# Altium schematic sheet Sheet1.SchDoc
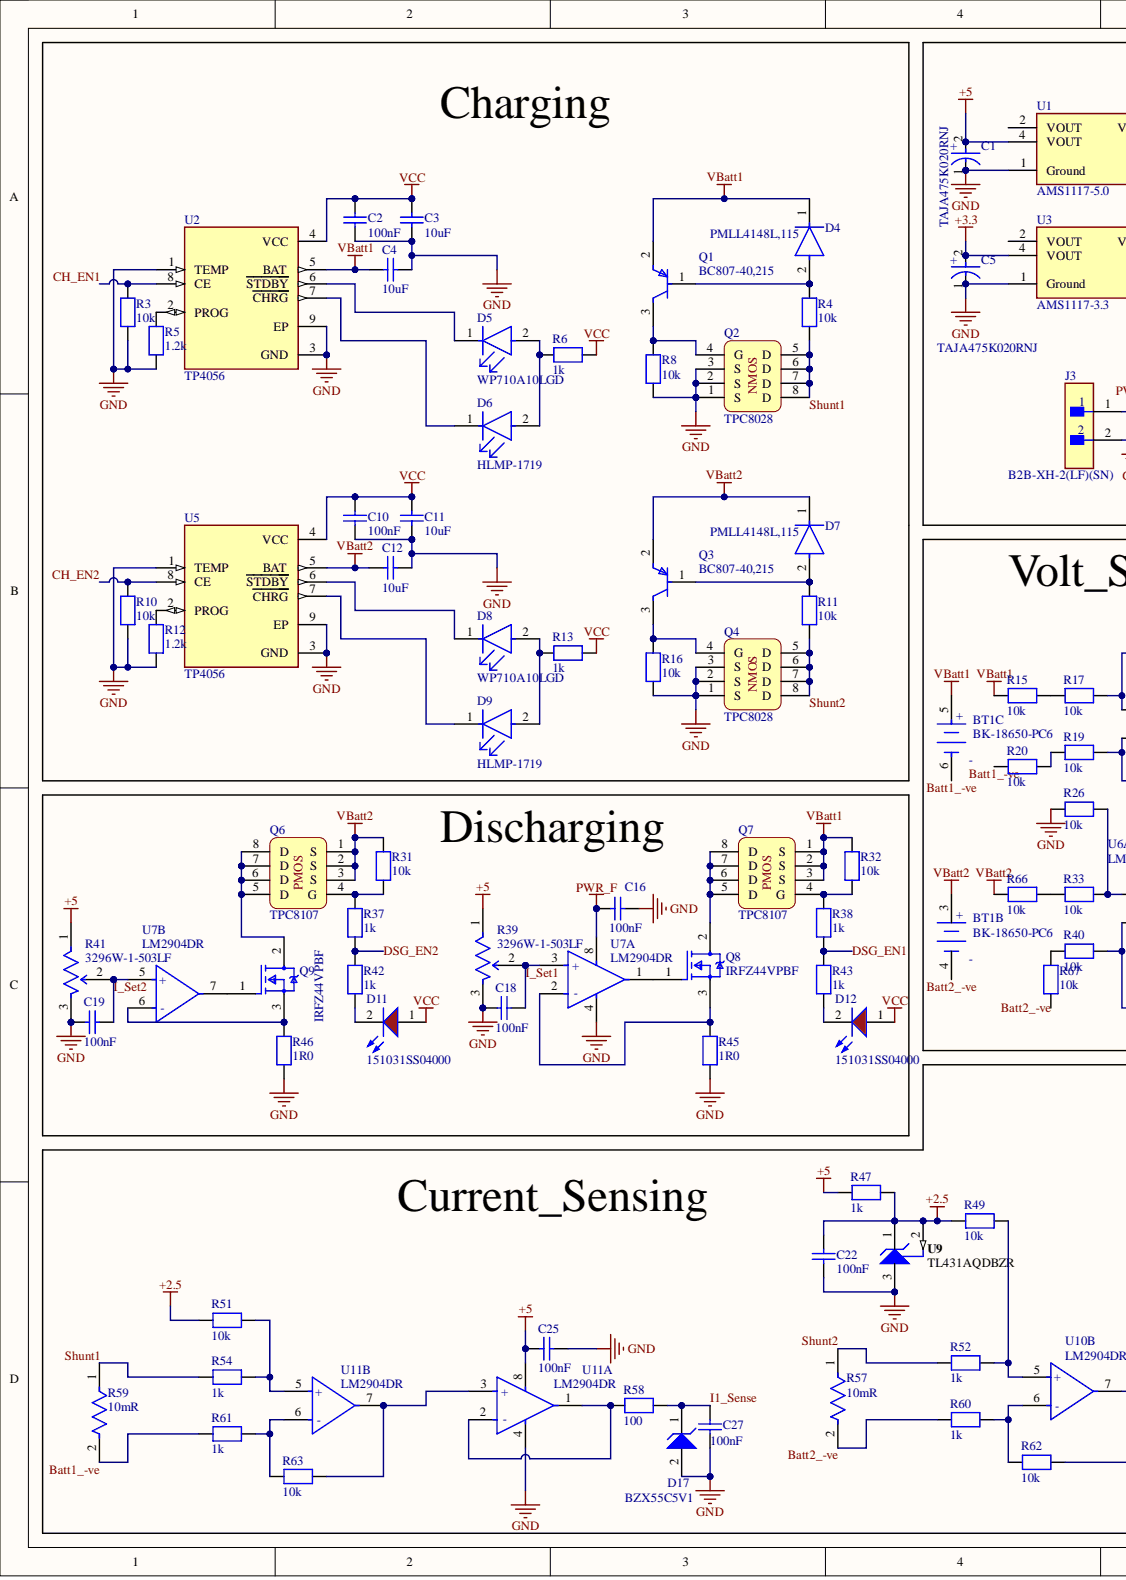
[diagram p0: Sheet1.SchDoc, left]
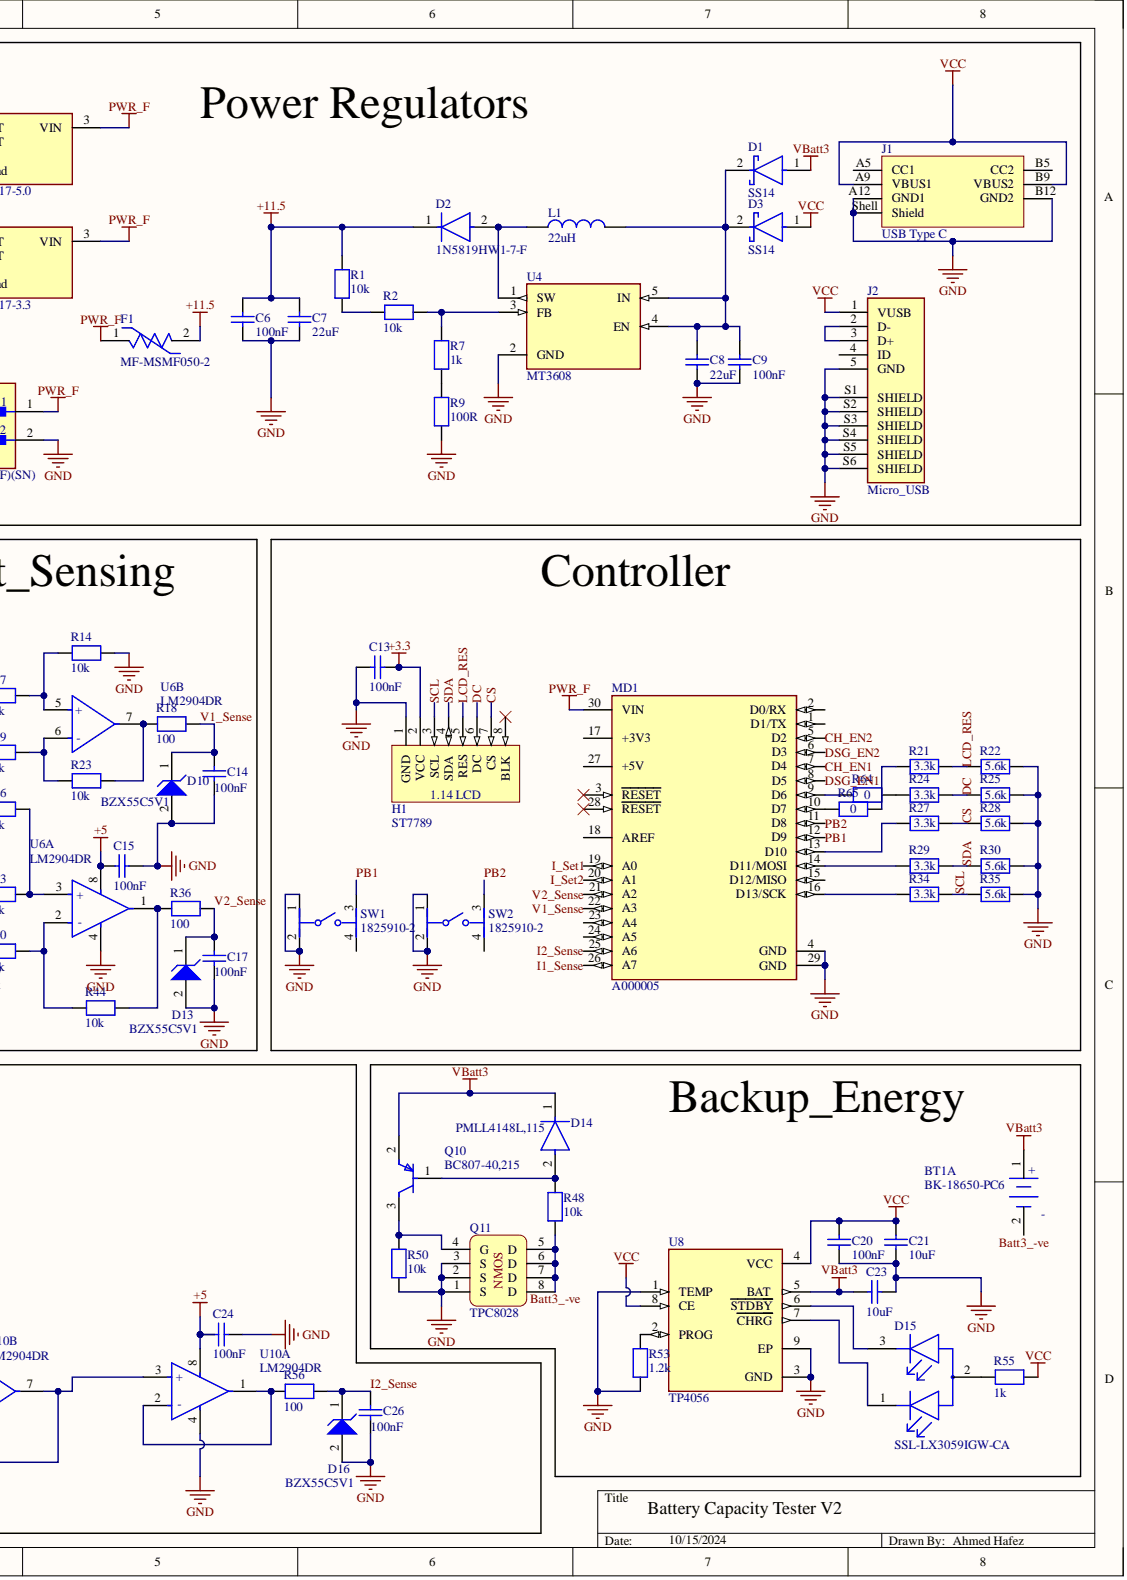
[diagram p1: Sheet1.SchDoc, right]

## Parts
BT1  BK-18650-PC6  [FP-BK-18650-PC6-MFG]  (parts A,B,C)
C1  TAJA475K020RNJ  [FP-TAJA-MFG]
C2  value 100nF  [CAP_0805]  (hidden comment: CAP_Generic)
C3  value 10uF  [CAP_0805]  (hidden comment: CAP_Generic)
C4  value 10uF  [CAP_0805]  (hidden comment: CAP_Generic)
C5  TAJA475K020RNJ  [FP-TAJA-MFG]
C6  value 100nF  [CAP_0603]  (hidden comment: CAP_Generic)
C7  value 22uF  [CAP_1206]  (hidden comment: CAP_Generic)
C8  value 22uF  [CAP_1206]  (hidden comment: CAP_Generic)
C9  value 100nF  [CAP_0603]  (hidden comment: CAP_Generic)
C10  value 100nF  [CAP_0805]  (hidden comment: CAP_Generic)
C11  value 10uF  [CAP_0805]  (hidden comment: CAP_Generic)
C12  value 10uF  [CAP_0805]  (hidden comment: CAP_Generic)
C13  value 100nF  [CAP_0603]  (hidden comment: CAP_Generic)
C14  value 100nF  [CAP_0603]  (hidden comment: CAP_Generic)
C15  value 100nF  [CAP_0603]  (hidden comment: CAP_Generic)
C16  value 100nF  [CAP_0603]  (hidden comment: CAP_Generic)
C17  value 100nF  [CAP_0603]  (hidden comment: CAP_Generic)
C18  value 100nF  [CAP_0603]  (hidden comment: CAP_Generic)
C19  value 100nF  [CAP_0603]  (hidden comment: CAP_Generic)
C20  value 100nF  [CAP_0805]  (hidden comment: CAP_Generic)
C21  value 10uF  [CAP_0805]  (hidden comment: CAP_Generic)
C22  value 100nF  [CAP_0603]  (hidden comment: CAP_Generic)
C23  value 10uF  [CAP_0805]  (hidden comment: CAP_Generic)
C24  value 100nF  [CAP_0603]  (hidden comment: CAP_Generic)
C25  value 100nF  [CAP_0603]  (hidden comment: CAP_Generic)
C26  value 100nF  [CAP_0603]  (hidden comment: CAP_Generic)
C27  value 100nF  [CAP_0603]  (hidden comment: CAP_Generic)
D1  SS14  [FP-403AE-MFG]
D2  1N5819HW1-7-F  [FP-SOD123F_Type_B-MFG]
D3  SS14  [FP-403AE-MFG]
D4  PMLL4148L,115  [FP-SOD80C-MFG]
D5  WP710A10LGD  [FP-WP710A10LGD-MFG]
D6  HLMP-1719  [FP-HLMP-1719-MFG]
D7  PMLL4148L,115  [FP-SOD80C-MFG]
D8  WP710A10LGD  [FP-WP710A10LGD-MFG]
D9  HLMP-1719  [FP-HLMP-1719-MFG]
D10  BZX55C5V1  [DO-35 (DO-204AH)]
D11  151031SS04000  [WL-TMRC_D3.95H5.3]
D12  151031SS04000  [WL-TMRC_D3.95H5.3]
D13  BZX55C5V1  [DO-35 (DO-204AH)]
D14  PMLL4148L,115  [FP-SOD80C-MFG]
D15  SSL-LX3059IGW-CA  [FP-SSL-LX3059IGW-CA-MFG]
D16  BZX55C5V1  [DO-35 (DO-204AH)]
D17  BZX55C5V1  [DO-35 (DO-204AH)]
F1  MF-MSMF050-2  [FP-MF-MSMF050-2-MFG]
H1  ST7789  [1.3-tft-ips-module-st7789]
J1  USB Type C  [632722100213]
J2  Micro_USB  [FCI_10118193-0001LF]
J3  B2B-XH-2(LF)(SN)  [FP-B2B-XH-2_LF_SN-MFG]
L1  value 22uH  [CD43-220M]  (hidden comment: CD43-220M)
MD1  A000005  [FP-A000005-MFG]
Q1  BC807-40,215  [FP-SOT23-IPC_A]
Q2  TPC8028  [FP-751-07-MFG]
Q3  BC807-40,215  [FP-SOT23-IPC_A]
Q4  TPC8028  [FP-751-07-MFG]
Q6  TPC8107  [FP-751-07-MFG]
Q7  TPC8107  [FP-751-07-MFG]
Q8  IRFZ44VPBF  [FP-PG-TO220-3-MFG]
Q9  IRFZ44VPBF  [FP-PG-TO220-3-MFG]
Q10  BC807-40,215  [FP-SOT23-IPC_A]
Q11  TPC8028  [FP-751-07-MFG]
R1  value 10k  [RES_0603]  (hidden comment: RES_Generic)
R2  value 10k  [RES_0603]  (hidden comment: RES_Generic)
R3  value 10k  [RES_0603]  (hidden comment: RES_Generic)
R4  value 10k  [RES_0603]  (hidden comment: RES_Generic)
R5  value 1.2k  [RES_0805]  (hidden comment: RES_Generic)
R6  value 1k  [RES_0603]  (hidden comment: RES_Generic)
R7  value 1k  [RES_0603]  (hidden comment: RES_Generic)
R8  value 10k  [RES_0603]  (hidden comment: RES_Generic)
R9  value 100R  [RES_0603]  (hidden comment: RES_Generic)
R10  value 10k  [RES_0603]  (hidden comment: RES_Generic)
R11  value 10k  [RES_0603]  (hidden comment: RES_Generic)
R12  value 1.2k  [RES_0805]  (hidden comment: RES_Generic)
R13  value 1k  [RES_0603]  (hidden comment: RES_Generic)
R14  value 10k  [RES_0805]  (hidden comment: RES_Generic)
R15  value 10k  [RES_0805]  (hidden comment: RES_Generic)
R16  value 10k  [RES_0603]  (hidden comment: RES_Generic)
R17  value 10k  [RES_0805]  (hidden comment: RES_Generic)
R18  value 100  [RES_0603]  (hidden comment: RES_Generic)
R19  value 10k  [RES_0805]  (hidden comment: RES_Generic)
R20  value 10k  [RES_0805]  (hidden comment: RES_Generic)
R21  value 3.3k  [RES_1206]  (hidden comment: RES_Generic)
R22  value 5.6k  [RES_0603]  (hidden comment: RES_Generic)
R23  value 10k  [RES_0805]  (hidden comment: RES_Generic)
R24  value 3.3k  [RES_1206]  (hidden comment: RES_Generic)
R25  value 5.6k  [RES_0603]  (hidden comment: RES_Generic)
R26  value 10k  [RES_0805]  (hidden comment: RES_Generic)
R27  value 3.3k  [RES_1206]  (hidden comment: RES_Generic)
R28  value 5.6k  [RES_0603]  (hidden comment: RES_Generic)
R29  value 3.3k  [RES_1206]  (hidden comment: RES_Generic)
R30  value 5.6k  [RES_0603]  (hidden comment: RES_Generic)
R31  value 10k  [RES_0603]  (hidden comment: RES_Generic)
R32  value 10k  [RES_0603]  (hidden comment: RES_Generic)
R33  value 10k  [RES_0805]  (hidden comment: RES_Generic)
R34  value 3.3k  [RES_1206]  (hidden comment: RES_Generic)
R35  value 5.6k  [RES_0603]  (hidden comment: RES_Generic)
R36  value 100  [RES_0603]  (hidden comment: RES_Generic)
R37  value 1k  [RES_0603]  (hidden comment: RES_Generic)
R38  value 1k  [RES_0603]  (hidden comment: RES_Generic)
R39  3296W-1-503LF  [FP-3296W-MFG]
R40  value 10k  [RES_0805]  (hidden comment: RES_Generic)
R41  3296W-1-503LF  [FP-3296W-MFG]
R42  value 1k  [RES_0603]  (hidden comment: RES_Generic)
R43  value 1k  [RES_0603]  (hidden comment: RES_Generic)
R44  value 10k  [RES_0805]  (hidden comment: RES_Generic)
R45  value 1R0  [RES_7W]  (hidden comment: RES_Generic)
R46  value 1R0  [RES_7W]  (hidden comment: RES_Generic)
R47  value 1k  [RES_0603]  (hidden comment: RES_Generic)
R48  value 10k  [RES_0603]  (hidden comment: RES_Generic)
R49  value 10k  [RES_0805]  (hidden comment: RES_Generic)
R50  value 10k  [RES_0603]  (hidden comment: RES_Generic)
R51  value 10k  [RES_0805]  (hidden comment: RES_Generic)
R52  value 1k  [RES_0805]  (hidden comment: RES_Generic)
R53  value 1.2k  [RES_0805]  (hidden comment: RES_Generic)
R54  value 1k  [RES_0805]  (hidden comment: RES_Generic)
R55  value 1k  [RES_0603]  (hidden comment: RES_Generic)
R56  value 100  [RES_0603]  (hidden comment: RES_Generic)
R57  Resistance: 10mR  [FP-RLP73V3AR010FTDF-MFG]  (hidden comment: RLP73V3AR010FTDF)
R58  value 100  [RES_0603]  (hidden comment: RES_Generic)
R59  Resistance: 10mR  [FP-RLP73V3AR010FTDF-MFG]  (hidden comment: RLP73V3AR010FTDF)
R60  value 1k  [RES_0805]  (hidden comment: RES_Generic)
R61  value 1k  [RES_0805]  (hidden comment: RES_Generic)
R62  value 10k  [RES_0805]  (hidden comment: RES_Generic)
R63  value 10k  [RES_0805]  (hidden comment: RES_Generic)
R64  value 0  [RES_1206]  (hidden comment: RES_Generic)
R65  value 0  [RES_1206]  (hidden comment: RES_Generic)
R66  value 10k  [RES_0805]  (hidden comment: RES_Generic)
R67  value 10k  [RES_0805]  (hidden comment: RES_Generic)
SW1  1825910-2  [FP-1825910-2-0]
SW2  1825910-2  [FP-1825910-2-0]
U1  AMS1117-5.0  [FP-AMS1117-IPC_A]
U2  TP4056  [SOP127P600X175-9N]
U3  AMS1117-3.3  [FP-AMS1117-IPC_A]
U4  MT3608  [SOT95P280X145-6N]
U5  TP4056  [SOP127P600X175-9N]
U6  LM2904DR  [FP-D0008A-IPC_A]  (parts A,B)
U7  LM2904DR  [FP-D0008A-IPC_A]  (parts A,B)
U8  TP4056  [SOP127P600X175-9N]
U9  TL431AQDBZR  [DBZ0003A_M]
U10  LM2904DR  [FP-D0008A-IPC_A]  (parts A,B)
U11  LM2904DR  [FP-D0008A-IPC_A]  (parts A,B)

## Nets
+2.5: C22.1 R47.1 R49.1 R51.1 U9.1 U9.2
+3.3: C5.2 C13.2 H1.2(VCC) U3.4(VOUT)
+5: C1.2 C15.2 C24.2 C25.2 R39.1 R41.1 R47.2 U1.4(VOUT) U6.8 U10.8 U11.8
+11.5: C6.2 C7.2 D2.1 F1.2 R1.1
GND: C1.1 C2.1 C3.1 C4.1 C5.1 C6.1 C7.1 C8.1 C9.1 C10.1 C11.1 C12.1 C13.1 C14.1 C15.1 C16.1 C17.1 C18.1 C19.1 C20.1 C21.1 C22.2 C23.1 C24.1 C25.1 C26.1 C27.1 D10.2 D13.2 D16.2 D17.2 H1.1(GND) J1.A12(GND1) J1.B12(GND2) J1.Shell(Shield) J2.5(GND) J2.S1(SHIELD) J2.S2(SHIELD) J2.S3(SHIELD) J2.S4(SHIELD) J2.S5(SHIELD) J2.S6(SHIELD) J3.2 MD1.4(GND) MD1.29(GND) Q2.1(S) Q2.2(S) Q2.3(S) Q4.1(S) Q4.2(S) Q4.3(S) Q11.1(S) Q11.2(S) Q11.3(S) R3.1 R5.1 R8.1 R9.2 R10.1 R12.1 R14.2 R16.1 R22.1 R25.1 R26.2 R28.1 R30.1 R35.1 R39.3 R41.3 R45.2 R46.2 R50.1 R53.1 SW1.1 SW1.2 SW2.1 SW2.2 U1.1(Ground) U2.1(TEMP) U2.3(GND) U2.9(EP) U3.1(Ground) U4.2(GND) U5.1(TEMP) U5.3(GND) U5.9(EP) U6.4 U7.4 U8.1(TEMP) U8.3(GND) U8.9(EP) U9.3 U10.4 U11.4
PWR_F: C16.2 F1.1 J3.1 MD1.30(VIN) U1.3(VIN) U3.3(VIN) U7.8
VBatt1: BT1.5 C4.2 D4.1 Q1.2 Q7.1(S) Q7.2(S) Q7.3(S) R15.1 R32.2 U2.5(BAT)
VBatt2: BT1.3 C12.2 D7.1 Q3.2 Q6.1(S) Q6.2(S) Q6.3(S) R31.2 R66.1 U5.5(BAT)
VBatt3: BT1.1 C23.2 D1.1 D14.1 Q10.2 U8.5(BAT)
VCC: C2.2 C3.2 C10.2 C11.2 C20.2 C21.2 D3.1 D11.1 D12.1 J1.A9(VBUS1) J1.B9(VBUS2) J2.1(VUSB) R6.2 R13.2 R55.2 U2.4(VCC) U5.4(VCC) U8.4(VCC) U8.8(CE)
Batt1_-ve: BT1.6 R20.1 R59.2 R61.1
Batt2_-ve: BT1.4 R57.2 R60.1 R67.1
Batt3_-ve: BT1.2 Q11.5(D) Q11.6(D) Q11.7(D) Q11.8(D) R48.1
CH_EN1: MD1.7(D4) R3.2 U2.8(CE)
CH_EN2: MD1.5(D2) R10.2 U5.8(CE)
CS: H1.7(CS) R27.1 R28.2
DC: H1.6(DC) R24.1 R25.2
DSG_EN1: MD1.8(D5) R38.1 R43.2
DSG_EN2: MD1.6(D3) R37.1 R42.2
I1_Sense: C27.2 D17.1 MD1.26(A7) R58.1
I2_Sense: C26.2 D16.1 MD1.25(A6) R56.1
I_Set1: C18.2 MD1.19(A0) R39.2 U7.3(+)
I_Set2: C19.2 MD1.20(A1) R41.2 U7.5(+)
LCD_RES: H1.5(RES) R21.1 R22.2
PB1: MD1.12(D9) SW1.3
PB2: MD1.11(D8) SW2.3
SCL: H1.3(SCL) R34.1 R35.2
SDA: H1.4(SDA) R29.1 R30.2
Shunt1: Q2.5(D) Q2.6(D) Q2.7(D) Q2.8(D) R4.1 R54.1 R59.1
Shunt2: Q4.5(D) Q4.6(D) Q4.7(D) Q4.8(D) R11.1 R52.1 R57.1
V1_Sense: C14.2 D10.1 MD1.22(A3) R18.1
V2_Sense: C17.2 D13.1 MD1.21(A2) R36.1
NetC8_2: C8.2 C9.2 D1.2 D3.2 L1.1 U4.4(EN) U4.5(IN)
NetD2_2: D2.2 L1.2 U4.1(SW)
NetD4_2: D4.2 Q1.1 R4.2
NetD5_1: D5.1 U2.6(STDBY)
NetD5_2: D5.2 D6.2 R6.1
NetD6_1: D6.1 U2.7(CHRG)
NetD7_2: D7.2 Q3.1 R11.2
NetD8_1: D8.1 U5.6(STDBY)
NetD8_2: D8.2 D9.2 R13.1
NetD9_1: D9.1 U5.7(CHRG)
NetD11_2: D11.2 R42.1
NetD12_2: D12.2 R43.1
NetD14_2: D14.2 Q10.1 R48.2
NetD15_1: D15.1 U8.7(CHRG)
NetD15_2: D15.2 R55.1
NetD15_3: D15.3 U8.6(STDBY)
NetJ2_2: J2.2(D-) J2.3(D+)
NetMD1_9: MD1.9(D6) R64.1
NetMD1_10: MD1.10(D7) R65.1
NetMD1_13: MD1.13(D10) R27.2
NetMD1_14: MD1.14(D11/MOSI) R29.2
NetMD1_16: MD1.16(D13/SCK) R34.2
NetQ1_3: Q1.3 Q2.4(G) R8.2
NetQ3_3: Q3.3 Q4.4(G) R16.2
NetQ6_4: Q6.4(G) R31.1 R37.2
NetQ6_5: Q6.5(D) Q6.6(D) Q6.7(D) Q6.8(D) Q9.2
NetQ7_4: Q7.4(G) R32.1 R38.2
NetQ7_5: Q7.5(D) Q7.6(D) Q7.7(D) Q7.8(D) Q8.2
NetQ8_1: Q8.1 U7.1
NetQ8_3: Q8.3 R45.1 U7.2(-)
NetQ9_1: Q9.1 U7.7
NetQ9_3: Q9.3 R46.1 U7.6(-)
NetQ10_3: Q10.3 Q11.4(G) R50.2
NetR1_2: R1.2 R2.2
NetR2_1: R2.1 R7.2 U4.3(FB)
NetR5_2: R5.2 U2.2(PROG)
NetR7_1: R7.1 R9.1
NetR12_2: R12.2 U5.2(PROG)
NetR14_1: R14.1 R17.2 U6.5(+)
NetR15_2: R15.2 R17.1
NetR18_2: R18.2 R23.2 U6.7
NetR19_1: R19.1 R20.2
NetR19_2: R19.2 R23.1 U6.6(-)
NetR21_2: R21.2 R65.2
NetR24_2: R24.2 R64.2
NetR26_1: R26.1 R33.2 U6.3(+)
NetR33_1: R33.1 R66.2
NetR36_2: R36.2 R44.2 U6.1
NetR40_1: R40.1 R67.2
NetR40_2: R40.2 R44.1 U6.2(-)
NetR49_2: R49.2 R52.2 U10.5(+)
NetR51_2: R51.2 R54.2 U11.5(+)
NetR53_2: R53.2 U8.2(PROG)
NetR56_2: R56.2 U10.1 U10.2(-)
NetR58_2: R58.2 U11.1 U11.2(-)
NetR60_2: R60.2 R62.1 U10.6(-)
NetR61_2: R61.2 R63.1 U11.6(-)
NetR62_2: R62.2 U10.3(+) U10.7
NetR63_2: R63.2 U11.3(+) U11.7

Not connected: H1 8(BLK); J1 A5(CC1) B5(CC2); J2 4(ID); MD1 1(D1/TX) 2(D0/RX) 3(RESET) 15(D12/MISO) 17(+3V3) 18(AREF) 23(A4) 24(A5) 27(+5V) 28(RESET); SW1 4; SW2 4; U1 2(VOUT); U3 2(VOUT)

## Library data of the parts
BT1 = CMP-136234-000001-1: BATT HOLDER 18650 3 CELL PC PIN; Manufacturer: MPD (Memory Protection Devices); Conn Gender: Female; Conn Orientation: Top View; Number Of Contacts: 3; Pitch: 72.9mm; Automotive: No; Category: Batt; Component Kind: Standard; ComponentLink2Description: Datasheet; ComponentLink2URL: http://www.memoryprotectiondevices.com/datasheets/BK-18650-PC6-datasheet.pdf; Contact Material: Nickel; Device Class L1: Connectors; Device Class L2: Power Connectors; Device Class L3: unset; Digikey Description: BATT HOLDER 18650 3 CELL PC PIN; Height: 22.04mm; Lead Free: Yes; Library Name: Altium Content Vault; Manufacturer Part Number: BK-18650-PC6; Octopart: https://octopart.com/bk-18650-pc6-memory+protection+devices-19049091; Package: 0; RoHS: TRUE; Temperature: -40°C to +180°C; Temperature Range High: +180°C; Temperature Range Low: -40°C
C1 C5 = CMP-04424-000323-2: 4.7uF 20V  ±10% 4Ω 1206  SMD Tantalum Capacitor; NexarPartId: 39985789; Capacitance: 4.7µF; Dissipation Factor: 6%; Leakage Current: 900nA; Life (Hours): 2000hours; Polarity: Polar; Q Factor: 6; Ripple Current: 137mA; Case Code (Imperial): 1206; Case Code (Metric): 3216; Case/Package: 1206; Component Kind: Standard; Depth: 1.6mm; Dielectric Material: Tantalum; ESR (Equivalent Series Resistance): 4Ω; Features: General Purpose; Height: 1.6mm; Height - Seated (Max): 1.8034mm; Lead Free: Lead Free; Lead Pitch: 1.1mm; Length: 3.2mm; Library Name: Altium Content Vault; Lifecycle Status: Production; Max Operating Temperature: 125°C; Min Operating Temperature: -55°C; Mount: PCB, Surface Mount; Number of Pins: 2; Packaging: Cut Tape (CT); Radiation Hardening: No; REACH SVHC: No SVHC; RoHS: Compliant; Schedule B: 8532210050; Termination: SMD/SMT; Tolerance: 10%; Voltage: 20V; Voltage Rating: 20V; Voltage Rating (DC): 20V; Width: 1.6mm
C2-C4 C6-C27 = CAP_Generic: Capacitor SMD Generic; Component Kind: Standard; Library Name: My_Library.IntLib
D1 D3 = CMP-2000-04943-2: DIODE SCHOTTKY 40V 1A SMA; Manufacturer: ON Semiconductor; Max Junction Temp: +125°C; Max Surge Forward Current: 40A; Peak Reverse Current: 0.2mA; Standoff Height: 0.05mm; Automotive: No; Category: Diode; Component Kind: Standard; ComponentLink2Description: Datasheet; ComponentLink2URL: https://www.onsemi.com/pub/Collateral/SS19-D.PDF; Device Class L1: Discrete Semiconductors; Device Class L2: Diodes; Device Class L3: Schottky Diodes; Digikey Description: DIODE SCHOTTKY 40V 1A SMA; Forward Current: 1A; Height: 2.5mm; Lead Free: Yes; Library Name: Altium Content Vault; Manufacturer Part Number: SS14; Max Repetitive Reverse Voltage: 40V; Mouser Description: Schottky Diodes & Rectifiers SMA 40V 1.0A; Octopart: https://octopart.com/ss14-on+semiconductor-29205033; Package: 403AE; RoHS: TRUE; Temperature: -65°C to +125°C; Temperature Range High: +125°C; Temperature Range Low: -65°C
D2 = CMP-12791-000028-1: DIODE SBR 40V 1A SOD123F; Manufacturer: Diodes Inc.; Capacitance: 30pF; Max Junction Temp: +125°C; Max Surge Forward Current: 30A; Peak Reverse Current: 0.5mA; Value: 30pF; Automotive: No; Category: Diode; Component Kind: Standard; ComponentLink2Description: Datasheet; ComponentLink2URL: https://www.diodes.com/assets/Datasheets/1N5819HW1.pdf; Device Class L1: Discrete Semiconductors; Device Class L2: Diodes; Device Class L3: Rectifier Diodes; Digikey Description: DIODE SBR 40V 1A SOD123F; Footprint Url: https://www.diodes.com/assets/Package-Files/SOD123F%20(Type%20B).pdf; Forward Current: 1A; Height: 1.15mm; Ipc Land Pattern Name: SOD350180X98-2; Lead Free: Yes; Library Name: Altium Content Vault; Manufacturer Part Number: 1N5819HW1-7-F; Max Repetitive Reverse Voltage: 40V; Mouser Description: Schottky Diodes & Rectifiers 1A SBR 40Vrrm 0.51Vf 0.5mA 28Vrms; Octopart: https://octopart.com/1n5819hw1-7-f-diodes+inc.-67250096; Package: SOD123F (Type B); Reverse Voltage: 40V; RoHS: TRUE; Temperature: -55°C to +125°C; Temperature Range High: +125°C; Temperature Range Low: -55°C
D4 D7 D14 = CMP-14399-000003-1: DIODE GEN PURP 75V 200MA LLDS; Manufacturer: Nexperia; Capacitance: 4pF; Max Junction Temp: +200°C; Max Repetitive Reverse Voltage: 100V; Max Surge Forward Current: 0.5A; Peak Reverse Current: 0.025uA; Value: 4pF; Ambient Temperature Range High: +200°C; Ambient Temperature Range Low: -65°C; Automotive: No; Category: Diode; Component Kind: Standard; ComponentLink2Description: Datasheet; ComponentLink2URL: https://assets.nexperia.com/documents/data-sheet/PMLL4148L_PMLL4448.pdf; Device Class L1: Discrete Semiconductors; Device Class L2: Diodes; Device Class L3: Rectifier Diodes; Digikey Description: DIODE GEN PURP 75V 200MA LLDS; Footprint Url: https://assets.nexperia.com/documents/outline-drawing/SOD80C.pdf; Forward Current: 200mA; Height: 1.6mm; Lead Free: Yes; Library Name: Altium Content Vault; Manufacturer Part Number: PMLL4148L,115; Octopart: https://octopart.com/pmll4148l%2C115-nexperia-78741557; Package: SOD80C; Reverse Voltage: 75V; RoHS: TRUE; Temperature: to +200°C; Temperature Range High: +200°C
D5 D8 = CMP-00682-20090258-1: WP710A10LGD LED Thru-Hole PCB Green T-1 3mm Green Lens 2 mA 568 nm 2 mcd Round; Dominant Wavelength: 568nm; Forward Current: 2mA; Forward Voltage: 1.9V; Lens Style: Diffused, Dome, Round, Tinted; Luminous Intensity: 2mcd; Max Junction Temperature (Tj): 110°C; Number of LEDs: 1; Power Dissipation: 62.5mW; Reverse Voltage: 5V; Viewing Angle: 40°; Case/Package: Radial; Color: Green; Component Kind: Standard; Height: 4.6mm; Illumination Color: Green; Lead Free: Lead Free; Lens Color: Green; Library Name: Altium Content Vault; Max Operating Temperature: 85°C; Min Operating Temperature: -40°C; Mount: Through Hole; NexarPartId: 20090258; Number of Pins: 3; Power Rating: 62.5mW; RoHS: Compliant; Schedule B: 8541402000, 8541402000; Test Current: 2mA; Wavelength: 568nm
D6 D9 = CMP-2000-06410-2: LED YELLOW DIFFUSED T-1 T/H; Manufacturer: Broadcom; Forward Current: 2mA; Forward Voltage: 1.8V; Led Orientation: Straight; Luminous Intensity: 2.1mcd; Power Dissipation: 17.5mW; Reverse Voltage: 5V; Viewing Angle: 50°; Wavelength: 585nm; Automotive: No; Category: Diode; Colour: Yellow; Component Kind: Standard; ComponentLink2Description: Datasheet; ComponentLink2URL: https://docs.broadcom.com/docs/AV02-1557EN; Device Class L1: Optoelectronics; Device Class L2: LEDs; Device Class L3: unset; Digikey Description: LED YELLOW DIFFUSED T-1 T/H; Lead Free: Yes; Library Name: Altium Content Vault; Manufacturer Part Number: HLMP-1719; Mouser Description: Standard LEDs - Through Hole Yellow Diffused 585nm 2.1mcd; Octopart: https://octopart.com/hlmp-1719-broadcom-71130405; Package: 0; RoHS: TRUE; Temperature: -40°C to +100°C; Temperature Range High: +100°C; Temperature Range Low: -40°C
D10 D13 D16 D17 = BZX55-Series: Zener Voltage Regulator, 500 mW, 2-Pin DO-35; Component Kind: Standard; Library Name: My_Library.IntLib
D11 D12 = CMP-1488-00005-2: NexarPartId: 29717802; Color: Red; Dominant Wavelength: 635nm; Forward Current: 100mA; Forward Voltage: 2V; Lens Size: 3mm; Lens Style: Circular, Diffused, Round; Luminous Intensity: 160mcd; Max Reverse Voltage (DC): 5V; Peak Wavelength: 640nm; Test Current: 20mA; Viewing Angle: 40°; Case/Package: Radial; Component Kind: Standard; Height: 5.3mm; Length: 3mm; Library Name: Altium Content Vault; Max Operating Temperature: 85°C; Min Operating Temperature: -40°C; Mount: Through Hole; Packaging: Bulk; REACH SVHC: Unknown; RoHS: Compliant; Wavelength: 635nm; Width: 3mm
D15 = CMP-57192-000011-1: T-3MM BI-COLOR LED 635NM RED/; Color: Green; Forward Current: 20mA; Forward Voltage: 2.2V; Lens Style: Dome; Luminous Intensity: 30mcd; Number of LEDs: 2; Viewing Angle: 60°; Component Kind: Standard; Illumination Color: Green; Lead Free: Lead Free; Library Name: Altium Content Vault; Max Operating Temperature: 85°C; Min Operating Temperature: -40°C; Mount: Through Hole; Number of Pins: 3; Package Quantity: 1000; Packaging: Bulk; RoHS Compliant: Yes; Wavelength: 635nm
F1 = CMP-2100-03653-2: PTC RESET FUSE 15V 500MA 1812; Manufacturer: Bourns; Automotive Grade: Grade 3; Current Rating: 100A; Hold Current: 0.5A; Resistance: 0.15-1Ω; Trip Current: 1A; Trip Time: 0.15s; Value: 0.15-1Ω; Ambient Temperature Range High: +85°C; Ambient Temperature Range Low: -40°C; Automotive: Yes; Category: Fuse; Component Kind: Standard; ComponentLink2Description: Datasheet; ComponentLink2URL: http://www.bourns.com/docs/Product-Datasheets/mfmsmf.pdf; Device Class L1: Circuit Protection; Device Class L2: PTC Resettable Fuses; Device Class L3: unset; Digikey Description: PTC RESET FUSE 15V 500MA 1812; Height: 0.85mm; Lead Free: Yes; Library Name: Altium Content Vault; Manufacturer Part Number: MF-MSMF050-2; Mouser Description: PTC Resettable Fuse 0.5A(hold) 1A(trip) 15V 100A 0.8W 0.15s 0.15Ohm SMD Solder Pad 1812 T/R; Octopart: https://octopart.com/mf-msmf050-2-bourns-28047; Package: 1812; RoHS: TRUE; Temperature: -40°C to +85°C; Temperature Range High: +85°C; Temperature Range Low: -40°C; Voltage Rating: 15V
H1 = ST7789: 1-14-tft-ips-module-st7789; Component Kind: Standard; Library Name: Display.IntLib
J1 = USB Type C: WR-COM USB Power Delivery Type C Receptacle Horizontal SMT; Component Kind: Standard; Library Name: Connectors.IntLib
J2 = Micro_USB: USB - micro B USB 2.0 Receptacle Connector 5 Position Surface Mount, Right Angle; Through Hole; Component Kind: Standard; Library Name: Connectors.IntLib
J3 = CMP-17439-000499-1: CONN HEADER VERT 2POS 2.5MM; Current Rating: 3A; Number of Poles: 2; Shape: Straight; Voltage Rating: 250V; Component Kind: Standard; Connector Type: Header; Contact Finish - Mating: TIN; Contact Material: Brass; Contact Shape: Square; Contact Type: Male Pin; Fastening Type: Detent; Flammability Rating: UL94 V-0; Height: 7mm; Housing Material: Nylon; Insulation Color: Natural; Insulation Material: Nylon; Length: 7.4mm; Library Name: Altium Content Vault; Max Operating Temperature: 85°C; Min Operating Temperature: -25°C; Mount: Through Hole; Number of Positions: 2; Number of Rows: 1; Packaging: Bulk; Pitch: 2.5mm; Series: XH; Termination: Press-Fit; Width: 5.75mm
L1 = CD43-220M: 760mA 22uH ±20% SMD Power Inductors ROHS; Component Kind: Standard; ComponentLink1Description: https://jlcpcb.com/partdetail/DMBJ-CD43220M/C2826645; ComponentLink1URL: JLCPCB; Library Name: Inductors.SchLib
MD1 = CMP-189986-000002-1: ARDUINO NANO ATMEGA328 EVAL BRD; Core Architecture: AVR; Data Bus Width: 8b; Evaluation Kit: Yes; Interface: I2C; Number of Bits: 8; Operating Supply Voltage: 12V; Component Kind: Standard; Height: 0.25inch; Length: 7inch; Library Name: Altium Content Vault; Package Quantity: 50; Packaging: Bulk; REACH SVHC: No SVHC; Series: AVR® ATmega; Weight: 0.358383oz; Width: 5inch
Q1 Q3 Q10 = CMP-14406-000042-1: TRANS PNP 45V 500MA TO236AB; Component Kind: Standard; Library Name: Altium Content Vault; RoHS Compliant: Yes
Q2 Q4 Q11 = TPC8028: TOSHIBA Field Effect Transistor Silicon N Channel MOS Type (U-MOS IV); Component Kind: Standard; Library Name: MOSFET.IntLib
Q6 Q7 = TPC8107: TOSHIBA Field Effect Transistor Silicon P Channel MOS Type (U-MOS IV); Component Kind: Standard; Library Name: MOSFET.IntLib
Q8 Q9 = CMP-32901-001154-1: MOSFET N-CH 60V 55A TO220AB; Drain to Source Resistance: 16.5mR; Element Configuration: Single; Gate to Source Voltage (Vgs): 20V; Input Capacitance: 1.812nF; Max Power Dissipation: 115W; Polarization: N; Threshold Voltage: 4V; Turn-Off Delay Time: 40ns; Turn-On Delay Time: 13ns; Case/Package: TO-220; Component Kind: Standard; Continuous Drain Current (ID): 55A; Drain to Source Breakdown Voltage: 60V; Drain to Source Voltage (Vdss): 60V; Halogen Free: Halogen Free; Height: 0.65inch; Lead Free: Lead Free; Length: 0.42inch; Library Name: Altium Content Vault; Max Dual Supply Voltage: 60V; Max Operating Temperature: 175°C; Min Operating Temperature: -55°C; Mount: Through Hole; Number of Pins: 3; Packaging: Rail/Tube; Power Dissipation: 115W; Rds On Max: 16.5mR; Reach SVHC Compliant: Yes; RoHS Compliant: Yes; Series: HEXFET®; Width: 0.19inch
R1-R38 R40 R42-R56 R58 R60-R67 = RES_Generic: Resistor SMD Generic; Tolerance: 5%; Component Kind: Standard; Library Name: My_Library.IntLib
R39 R41 = CMP-1747-00001-8: For AMP; Insulation Resistance: 1GR; Max Power Dissipation: 500mW; Number of Turns: 25; Resistance: 50kR; Resistance Tolerance: 10%; Temperature Coefficient: 100ppm/°C; Color: Blue; Component Kind: Standard; Contact Plating: Tin; Depth: 4.83mm; Height: 11.55mm; Lead Free: Lead Free; Length: 9.53mm; Library Name: Altium Content Vault; Max Operating Temperature: 125°C; Military Standard: Not; Min Operating Temperature: -55°C; Mount: Through Hole; Package Quantity: 50; Packaging: Rail/Tube; Power Rating: 500mW; Radiation Hardening: No; Reach SVHC Compliant: Yes; Resistor Type: Cermet; RoHS Compliant: Yes; Series: 3296; Taper: Linear; Technology: CERMET; Termination: Pin; Tolerance: 10%; Weight: 0.03oz; Width: 9.53mm
R57 R59 = CMP-03211-011418-1: RES 0.01 OHM 1% 2W 2512; Number of Resistors: 1; Temperature Coefficient: 600ppm/°C; Component Kind: Standard; ELV: Compliant; Library Name: Altium Content Vault; Mount: Surface Mount; Number of Terminations: 2; Packaging: Tape and Reel; Power Rating: 2W; Resistor Type: Thick Film; RoHS Compliant: Yes; Termination: Solder; Tolerance: 1%
SW1 SW2 = CMP-03407-000004-1: SWITCH TACTILE SPST-NO 0.05A 24V; Manufacturer: TE; Contact Current Rating: 50mA; Contact Resistance: 100mΩ; Electromechanical Life: 100000Cycles; Operating Force: 160gf; Automotive: No; Category: Switch; Component Kind: Standard; ComponentLink2Description: Datasheet; ComponentLink2URL: https://www.te.com/commerce/DocumentDelivery/DDEController?Action=showdoc&DocId=Customer+Drawing%7F1825910%7FC10%7Fpdf%7FEnglish%7FENG_CD_1825910_C10.pdf%7F1825910-2; Device Class L1: Electromechanical; Device Class L2: Switches; Device Class L3: Tactile Switches; Digikey Description: SWITCH TACTILE SPST-NO 0.05A 24V; Height: 4.6mm; Lead Free: Yes; Library Name: Altium Content Vault; Manufacturer Part Number: 1825910-2; Mount: Through Hole; Octopart: https://octopart.com/1825910-2-te+connectivity-42270502; Package: 0; RoHS: TRUE; Temperature: -35°C to +85°C; Temperature Range High: +85°C; Temperature Range Low: -35°C; Throw Configuration: SPST-NO; Voltage Rating Dc: 24V
U1 = CMP-209535-000001-1: LDO Voltage Regulators 1A, 5.0V; Manufacturer: Advanced Monolithic Systems; Manufacturer Part Number: AMS1117-3.3; Dropout Voltage: 1.1V; Max Junction Temp: +125°C; Max Power Dissipation: 1.2W; Max Supply Voltage: 15V; Nominal Supply Current: 5mA; Number Of Outputs: 1; Output Type: Fixed; Output Voltage: 3.3V; Standoff Height: 0.64mm; Automotive: No; Category: IC; Component Kind: Standard; ComponentLink2Description: Datasheet; ComponentLink2URL: http://www.advanced-monolithic.com/pdf/ds1117.pdf; Device Class L1: Integrated Circuits (ICs); Device Class L2: Power Management ICs; Device Class L3: Voltage Regulators - Linear; Height: 1.8mm; Ipc Land Pattern Name: SOT229P700X180-4; Lead Free: Yes; Library Name: Altium Content Vault; Octopart: https://octopart.com/ams1117-3.3-advanced+monolithic+systems-25899300; Output Current: 1A; Package: AMS1117; RoHS: TRUE; Temperature: -40°C to +125°C; Temperature Range High: +125°C; Temperature Range Low: -40°C
U2 U5 U8 = TP4056: Complete single cell Li-Ion battery with a constant current / constant voltage linear charger; MF: NanJing Top Power ASIC Corp.; Availability: Not in stock; Check_prices: https://www.snapeda.com/parts/TP4056/NanJing+Top+Power+ASIC+Corp./view-part/?ref=eda; Component Kind: Standard; Library Name: My_Library.IntLib; MP: TP4056; Package: Package; Price: None; SnapEDA_Link: https://www.snapeda.com/parts/TP4056/NanJing+Top+Power+ASIC+Corp./view-part/?ref=snap
U3 = CMP-209535-000001-1: LDO Voltage Regulators 1A, 3.3V; Manufacturer: Advanced Monolithic Systems; Dropout Voltage: 1.1V; Max Junction Temp: +125°C; Max Power Dissipation: 1.2W; Max Supply Voltage: 15V; Nominal Supply Current: 5mA; Number Of Outputs: 1; Output Type: Fixed; Standoff Height: 0.64mm; Automotive: No; Category: IC; Component Kind: Standard; ComponentLink2Description: Datasheet; ComponentLink2URL: http://www.advanced-monolithic.com/pdf/ds1117.pdf; Device Class L1: Integrated Circuits (ICs); Device Class L2: Power Management ICs; Device Class L3: Voltage Regulators - Linear; Height: 1.8mm; Ipc Land Pattern Name: SOT229P700X180-4; Lead Free: Yes; Library Name: Altium Content Vault; Manufacturer Part Number: AMS1117-3.3; Octopart: https://octopart.com/ams1117-3.3-advanced+monolithic+systems-25899300; Output Current: 1A; Output Voltage: 3.3V; Package: AMS1117; RoHS: TRUE; Temperature: -40°C to +125°C; Temperature Range High: +125°C; Temperature Range Low: -40°C
U4 = MT3608: High Efficiency 1.2MHz2A Step Up Converter; MF: Aerosemi Technology Co., Ltd; Availability: Not in stock; Check_prices: https://www.snapeda.com/parts/MT3608/Aerosemi+Technology+Co.%252C+Ltd/view-part/?ref=eda; Component Kind: Standard; Library Name: My_Library.IntLib; MP: MT3608; Package: Package; Price: None; SnapEDA_Link: https://www.snapeda.com/parts/MT3608/Aerosemi+Technology+Co.%252C+Ltd/view-part/?ref=snap
U6 U7 U10 U11 = CMP-04895-000052-2: IC OPAMP GP 2 CIRCUIT 8SOIC; Manufacturer: Texas Instruments; Gain Bandwidth: 0.7MHz; Input Bias Current: -0.02uA; Input Offset Voltage: 3mV; Max Dual Supply Voltage: 13V; Max Junction Temp: +150°C; Max Supply Voltage: 26V; Min Dual Supply Voltage: 1.5V; Min Supply Voltage: 3V; Nominal Supply Current: 350-500uA; Number Of Channels: 2; Output Current Per Channel: 20mA; Standoff Height: 0.11mm; Voltage Gain: 100V/mV; Ambient Temperature Range High: +125°C; Ambient Temperature Range Low: -40°C; Automotive: No; Category: IC; Component Kind: Standard; ComponentLink2Description: Datasheet; ComponentLink2URL: https://www.ti.com/lit/ds/symlink/lm2904.pdf; Device Class L1: Integrated Circuits (ICs); Device Class L2: Linear ICs; Device Class L3: Amplifiers - Op Amps; Digikey Description: IC OPAMP GP 2 CIRCUIT 8SOIC; Height: 1.75mm; Lead Free: Yes; Library Name: Altium Content Vault; Manufacturer Part Number: LM2904DR; Mouser Description: Operational Amplifiers - Op Amps Dual GP Op Amp; Octopart: https://octopart.com/lm2904dr-texas+instruments-409181; Package: D0008A; RoHS: TRUE; Temperature: -40°C to +125°C; Temperature Range High: +125°C; Temperature Range Low: -40°C
U9 = CMP-0366-01210-2: Adjustable Precision Shunt Regulator, 34 ppm / degC, 100 mA, -40 to 125 degC, 3-pin SOT-23 (DBZ), Green (RoHS & no Sb/Br); Manufacturer: Texas Instruments; Initial Accuracy @ 25/deg C(%): 1; Iout/Iz(Max)(mA): 100; Min Iz for Regulation(uA): 600; Rating: Catalog; SubFamily: Shunt Voltage Reference; Temp Coeff(Max)(ppm/ degree C): 92; Temp Coeff(Typ)(ppm/ degree C): 34; VO Adj(Max)(V): 36; VO Adj(Min)(V): 2.495; Code_JEDEC: TO-236; Component Kind: Standard; ComponentLink1Description: Manufacturer URL; ComponentLink1URL: http://www.ti.com/; ComponentLink2Description: Datasheet; ComponentLink2URL: http://www.ti.com/general/docs/lit/getliterature.tsp?genericPartNumber=TL431A&fileType=pdf; ComponentLink3Description: Package Specification; ComponentLink3URL: http://www.ti.com/litv/pdf/mpds108a; DatasheetVersion: SLVS543M; Eco-Plan: Green (RoHS & no Sb/Br); Library Name: Altium Content Vault; Mounting Technology: Surface Mount; PackageDescription: 3-Pin Plastic Small Outline, Body 2.92 x 1.3 mm, Pitch 0.95 mm; PackageReference: DBZ0003A; PackageVersion: 4203227/B; PartNumber: TL431AQDBZR
